# Altium schematic sheet Main.SchDoc (continued)

## Library data of the parts
C1-C7 C9-C19 C21 C22 = CAP 0805 50V: Capacitor SMD 0805 50V; Component Kind: Standard; Library Name: Schlib.SchLib
C8 C23 C24 = CAP 1210 25V: Capacitor SMD 1210 25V; Component Kind: Standard; Library Name: Schlib.SchLib
C20 = CAP 1210 50V: Capacitor SMD 1210 50V; Component Kind: Standard; Library Name: Schlib.SchLib
D1 D4 D5 D7 D10 = LED 0805 BLUE: LED 0805 GREEN SMD; Component Kind: Standard; Library Name: Schlib.SchLib
D2 D6 D8 D9 = ESDS304DBVR: Trans Voltage Suppressor Diode, 85W, 3.6V V(RWM), Unidirectional, 4 Element, Silicon; Manufacturer: Texas Instruments; Category: TVS Diodes; Component Kind: Standard; ComponentLink1Description: https://www.ti.com:443/lit/ds/symlink/esds302.pdf?src-supplier=IHS+Markit; ComponentLink1URL: https://www.ti.com:443/lit/ds/symlink/esds302.pdf?src-supplier=IHS+Markit; ComponentLink2Description: http://www.ti.com/lit/ds/symlink/esds302.pdf?src-supplier=Digikey; ComponentLink2URL: http://www.ti.com/lit/ds/symlink/esds302.pdf?src-supplier=Digikey; Diode Type: TRANS VOLTAGE SUPPRESSOR; JESD-609 Code: e3; Library Name: Schlib.SchLib; Manufacturer Part Number: ESDS304DBVR; Moisture Sensitivity Level: 1; Pricing: 1=0,45, 10=0,304, 50=0,304, 100=0,282, 1000=0,202, 10000=0,15 (USD); Reach SVHC Compliant: Yes; RoHS: Yes; RoHS Compliant: Yes; Stock: 132; Supplier: Mouser; Supplier Part Number: 595-ESDS304DBVR; Terminal Finish: Tin
D3 = ESDA6V1BC6: Trans Voltage Suppressor Diode, 80W, 5V V(RWM), Bidirectional, 4 Element, Silicon; Manufacturer: STMicroelectronics; Max Breakdown Voltage: 8V; Min Breakdown Voltage: 6.1V; Number of Elements: 4; Package Body Material: Plastic; Qualification Status: Not Qualified; Time @ Peak Reflow Temperature-Max (s): 30s; Category: TVS Diodes; Component Kind: Standard; ComponentLink1Description: https://datasheet.ciiva.com:443/pdfs/VipMasterIC/IC/SGST/SGSTS47549/SGSTS47549-1.pdf?src-supplier=IHS+Markit; ComponentLink1URL: https://datasheet.ciiva.com:443/pdfs/VipMasterIC/IC/SGST/SGSTS47549/SGSTS47549-1.pdf?src-supplier=IHS+Markit; ComponentLink2Description: https://datasheet.ciiva.com/14186/esda6v1bc6-st-eng-tds-14186554.pdf?src-supplier=Distrelec; ComponentLink2URL: https://datasheet.ciiva.com/14186/esda6v1bc6-st-eng-tds-14186554.pdf?src-supplier=Distrelec; ComponentLink3Description: https://datasheet.ciiva.com/4507/cd00001906-94538-4507893.pdf?src-supplier=Mouser; ComponentLink3URL: https://datasheet.ciiva.com/4507/cd00001906-94538-4507893.pdf?src-supplier=Mouser; ComponentLink4Description: https://datasheet.ciiva.com/15143/getdatasheetpartid-148472-15143793.pdf?src-supplier=Verical; ComponentLink4URL: https://datasheet.ciiva.com/15143/getdatasheetpartid-148472-15143793.pdf?src-supplier=Verical; ComponentLink5Description: https://datasheet.ciiva.com/7662/1671767-7662124.pdf?src-supplier=Element14; ComponentLink5URL: https://datasheet.ciiva.com/7662/1671767-7662124.pdf?src-supplier=Element14; ComponentLink6Description: https://datasheet.ciiva.com/338/cd00001906-338704.pdf?src-supplier=Digikey; ComponentLink6URL: https://datasheet.ciiva.com/338/cd00001906-338704.pdf?src-supplier=Digikey; ComponentLink7Description: https://datasheet.ciiva.com/338/cd00001906-338705.pdf?src-supplier=Digikey; ComponentLink7URL: https://datasheet.ciiva.com/338/cd00001906-338705.pdf?src-supplier=Digikey; ComponentLink8Description: https://datasheet.ciiva.com/11194/cd00001906-11194053.pdf?src-supplier=Avnet; ComponentLink8URL: https://datasheet.ciiva.com/11194/cd00001906-11194053.pdf?src-supplier=Avnet; Diode Element Material: SILICON; Diode Type: TRANS VOLTAGE SUPPRESSOR; JESD-30 Code: R-PDSO-G6; JESD-609 Code: e4; Library Name: Schlib.SchLib; Manufacturer Part Number: ESDA6V1BC6; Max Operating Temperature: 150°C; Moisture Sensitivity Level: 1; Non-rep Peak Rev Power Dis-Max: 80W; Package Shape: Rectangular; Package Style: SMALL OUTLINEMeter; Peak Reflow Temperature (Cel): 260°C; Pins: 6; Polarity: Bidirectional; Pricing: 3000=0,1532 (USD); Reach SVHC Compliant: Yes; Rep Pk Reverse Voltage-Max: 5V; RoHS: Yes; RoHS Compliant: Yes; Stock: 84000; Supplier: Arrow Electronics; Supplier Part Number: ESDA6V1BC6; Surface Mount: Yes; Technology: AVALANCHE; Terminal Finish: Nickel; Terminal Form: Gull Wing; Terminal Position: DUAL
D11 = SS26-E3/52T: Rectifier Diode, Schottky, 1 Phase, 1 Element, 2A, 60V V(RRM), Silicon, DO-214AA; Manufacturer: Vishay Semiconductors; Application(s): EFFICIENCY; Forward Voltage: 700mV; Non-rep Pk Forward Current-Max: 75A; Number of Elements: 1; Package Body Material: Plastic; Qualification Status: Not Qualified; Category: Rectifier Diodes; Component Kind: Standard; ComponentLink1Description: https://datasheet.ciiva.com:443/pdfs/VipMasterIC/IC/VISH/VISH-S-A0003397022/VISH-S-A0003397022-1.pdf?src-supplier=IHS+Markit; ComponentLink1URL: https://datasheet.ciiva.com:443/pdfs/VipMasterIC/IC/VISH/VISH-S-A0003397022/VISH-S-A0003397022-1.pdf?src-supplier=IHS+Markit; ComponentLink2Description: http://www.farnell.com/datasheets/2243773.pdf?src-supplier=Farnell; ComponentLink2URL: http://www.farnell.com/datasheets/2243773.pdf?src-supplier=Farnell; ComponentLink3Description: http://www.mouser.com/ds/2/427/ss22-59686.pdf?src-supplier=Mouser; ComponentLink3URL: http://www.mouser.com/ds/2/427/ss22-59686.pdf?src-supplier=Mouser; ComponentLink4Description: http://components.arrow.com/part/dataview/42820119S9715575N5680?src-supplier=Arrow+Electronics; ComponentLink4URL: http://components.arrow.com/part/dataview/42820119S9715575N5680?src-supplier=Arrow+Electronics; ComponentLink5Description: https://www.alliedelec.com/m/d/a5cdc6a9796d04f8094106d10cac2262.pdf?src-supplier=Allied+Electronics; ComponentLink5URL: https://www.alliedelec.com/m/d/a5cdc6a9796d04f8094106d10cac2262.pdf?src-supplier=Allied+Electronics; ComponentLink6Description: http://www.produktinfo.conrad.com/datenblaetter/575000-599999/596527-da-01-en-DIODE_SCHOTTKY_SS26_E3_52T_DO_214AA_VIS.pdf?src-supplier=Conrad; ComponentLink6URL: http://www.produktinfo.conrad.com/datenblaetter/575000-599999/596527-da-01-en-DIODE_SCHOTTKY_SS26_E3_52T_DO_214AA_VIS.pdf?src-supplier=Conrad; ComponentLink7Description: http://www.vishay.com/docs/88748/88748.pdf?src-supplier=Future+Electronics; ComponentLink7URL: http://www.vishay.com/docs/88748/88748.pdf?src-supplier=Future+Electronics; ComponentLink8Description: https://datasheet.octopart.com/SS26-E3-52T-Vishay-datasheet-11549126.pdf?src-supplier=Newark; ComponentLink8URL: https://datasheet.octopart.com/SS26-E3-52T-Vishay-datasheet-11549126.pdf?src-supplier=Newark; ComponentLink9Description: https://datasheet.octopart.com/SS26-E3-52T-Vishay-datasheet-78862754.pdf?src-supplier=Element14; ComponentLink9URL: https://datasheet.octopart.com/SS26-E3-52T-Vishay-datasheet-78862754.pdf?src-supplier=Element14; ComponentLink10Description: http://www.farnell.com/datasheets/2243773.pdf?src-supplier=Newark; ComponentLink10URL: http://www.farnell.com/datasheets/2243773.pdf?src-supplier=Newark; ComponentLink11Description: https://datasheet.octopart.com/SS26-E3/52T-Vishay-datasheet-126433.pdf?src-supplier=Digikey; ComponentLink11URL: https://datasheet.octopart.com/SS26-E3/52T-Vishay-datasheet-126433.pdf?src-supplier=Digikey; ComponentLink12Description: http://www.vishay.com/docs/88748/88748.pdf?src-supplier=Avnet; ComponentLink12URL: http://www.vishay.com/docs/88748/88748.pdf?src-supplier=Avnet; ComponentLink13Description: http://www.vishay.com/docs/88748/ss22.pdf?src-supplier=Digikey; ComponentLink13URL: http://www.vishay.com/docs/88748/ss22.pdf?src-supplier=Digikey; ComponentLink14Description: http://docs-usa.electrocomponents.com/techlib:/itc_doclist_US.htm?stockno=7000962&src-supplier=Allied+Electronics; ComponentLink14URL: http://docs-usa.electrocomponents.com/techlib:/itc_doclist_US.htm?stockno=7000962&src-supplier=Allied+Electronics; ComponentLink15Description: https://datasheet.octopart.com/SS26-E3-52T-Vishay-datasheet-42673615.pdf?src-supplier=TME; ComponentLink15URL: https://datasheet.octopart.com/SS26-E3-52T-Vishay-datasheet-42673615.pdf?src-supplier=TME; Diode Element Material: SILICON; Diode Type: RECTIFIER; JEDEC-95 Code: DO-214AA; JESD-30 Code: R-PDSO-C2; JESD-609 Code: e3; Library Name: Schlib.SchLib; Manufacturer Part Number: SS26-E3/52T; Max Operating Temperature: 150°C; Max Output current: 2A; Min Operating Temperature: -65°C; Moisture Sensitivity Level: 1; Number of Phases: 1; Package Shape: Rectangular; Package Style: SMALL OUTLINEMeter; Peak Reflow Temperature (Cel): 260°C; Pins: 2; Pricing: 750=0,1023 (USD); Reach SVHC Compliant: No; Rep Pk Reverse Voltage-Max: 60V; RoHS: Yes; RoHS Compliant: Yes; Stock: 1482000; Supplier: Arrow Electronics; Supplier Part Number: SS26-E3/52T; Surface Mount: Yes; Technology: SCHOTTKY; Terminal Finish: Tin; Terminal Form: C BEND; Terminal Position: DUAL
D12 = SMBJ8.5CA: Diode TVS Single Bi-Dir 8.5V 600W 2-Pin SMB; Manufacturer: Bourns; Breakdown Voltage: 10.8V; Clamping Voltage: 137V; Diode Type: ZENER; Direction: Bidirectional; Leakage Current: 1uA; Max Reverse Leakage Current: 40uA; Max Surge Current: 100A; Min Breakdown Voltage: 9.44V; Number of Bidirectional Channels: 1; Operating Supply Voltage: 3.5V; Peak Pulse Current: 41.7A; Power Line Protection: No; Reverse Breakdown Voltage: 94.4V; Test Current: 1mA; Case/Package: DO-214AA; Category: TVS Diodes; Component Kind: Standard; ComponentLink1Description: https://datasheet.ciiva.com/4510/smbj-51809-4510857.pdf?src-supplier=Mouser; ComponentLink1URL: https://datasheet.ciiva.com/4510/smbj-51809-4510857.pdf?src-supplier=Mouser; ComponentLink2Description: https://datasheet.ciiva.com/3022/smbj-3022665.pdf?src-supplier=Avnet; ComponentLink2URL: https://datasheet.ciiva.com/3022/smbj-3022665.pdf?src-supplier=Avnet; ComponentLink3Description: https://datasheet.ciiva.com/3022/smbj-3022666.pdf?src-supplier=Avnet; ComponentLink3URL: https://datasheet.ciiva.com/3022/smbj-3022666.pdf?src-supplier=Avnet; ComponentLink4Description: https://datasheet.ciiva.com/334/smbj-334791.pdf?src-supplier=Digikey; ComponentLink4URL: https://datasheet.ciiva.com/334/smbj-334791.pdf?src-supplier=Digikey; ComponentLink5Description: https://datasheet.ciiva.com/334/smbj-334792.pdf?src-supplier=Digikey; ComponentLink5URL: https://datasheet.ciiva.com/334/smbj-334792.pdf?src-supplier=Digikey; ComponentLink6Description: https://datasheet.ciiva.com/334/smbj-334790.pdf?src-supplier=Digikey; ComponentLink6URL: https://datasheet.ciiva.com/334/smbj-334790.pdf?src-supplier=Digikey; ComponentLink7Description: https://datasheet.ciiva.com/3022/smbj-3022670.pdf?src-supplier=Avnet; ComponentLink7URL: https://datasheet.ciiva.com/3022/smbj-3022670.pdf?src-supplier=Avnet; ComponentLink8Description: https://datasheet.ciiva.com/334/smbj-334764.pdf?src-supplier=Digikey; ComponentLink8URL: https://datasheet.ciiva.com/334/smbj-334764.pdf?src-supplier=Digikey; ComponentLink9Description: https://datasheet.ciiva.com/334/smbj-334765.pdf?src-supplier=Digikey; ComponentLink9URL: https://datasheet.ciiva.com/334/smbj-334765.pdf?src-supplier=Digikey; ComponentLink10Description: https://datasheet.ciiva.com/334/smbj-334763.pdf?src-supplier=Digikey; ComponentLink10URL: https://datasheet.ciiva.com/334/smbj-334763.pdf?src-supplier=Digikey; Library Name: Schlib.SchLib; Manufacturer Part Number: SMBJ8.5CA; Max Breakdown Voltage: 10.8V; Max Operating Temperature: 150°C; Max Power Dissipation: 600W; Min Operating Temperature: -55°C; Mount: Surface Mount; Number of Channels: 1; Number of Elements: 1; Number of Pins: 2; Packaging: Tape and Reel; Peak Pulse Power: 600W; Polarity: Bidirectional; Pricing 1: 12000=0,0994, 18000=0,0973, 30000=0,0952, 60000=0,0931, 120000=0,091, 600000=0,0889, 1200000=0,0875 (USD); Radiation Hardening: No; Reach SVHC Compliant: No; Reverse Standoff Voltage: 8.5V; RoHS: Yes; RoHS Compliant: Yes; Series: SMBJ; Supplier 1: Avnet; Termination: SMD/SMT
D13 D14 = LED 0805 RED: LED 0805 RED SMD; Component Kind: Standard; Library Name: Schlib.SchLib
F1 = 0ZCG0150BF2C: PTC RESTTBLE 1.50A 24V CHIP 1812; Manufacturer: Bel; Current Rating: 100A; Category: PTC Resettable Fuses; Component Kind: Standard; ComponentLink1Description: http://www.farnell.com/datasheets/2571640.pdf?src-supplier=Farnell; ComponentLink1URL: http://www.farnell.com/datasheets/2571640.pdf?src-supplier=Farnell; ComponentLink2Description: http://www.farnell.com/datasheets/2571640.pdf?src-supplier=Element14; ComponentLink2URL: http://www.farnell.com/datasheets/2571640.pdf?src-supplier=Element14; ComponentLink3Description: https://www.belfuse.com/resources/CircuitProtection/datasheets/0ZCG%20Nov2016.pdf?src-supplier=Digikey; ComponentLink3URL: https://www.belfuse.com/resources/CircuitProtection/datasheets/0ZCG%20Nov2016.pdf?src-supplier=Digikey; ComponentLink4Description: http://www.farnell.com/datasheets/2571640.pdf?src-supplier=Newark; ComponentLink4URL: http://www.farnell.com/datasheets/2571640.pdf?src-supplier=Newark; ComponentLink5Description: http://www.belfuse.com/pdfs/0ZCG.pdf?src-supplier=Avnet; ComponentLink5URL: http://www.belfuse.com/pdfs/0ZCG.pdf?src-supplier=Avnet; ComponentLink6Description: https://datasheet.octopart.com/0ZCG0150BF2C-Bel-Fuse-datasheet-138895723.pdf?src-supplier=Electro+Sonic; ComponentLink6URL: https://datasheet.octopart.com/0ZCG0150BF2C-Bel-Fuse-datasheet-138895723.pdf?src-supplier=Electro+Sonic; Height: 1.55mm; Library Name: Schlib.SchLib; Manufacturer Part Number: 0ZCG0150BF2C; Pricing 1: 2000=0,10628, 4000=0,10217, 8000=0,09874, 12000=0,09531, 16000=0,09188, 20000=0,08846, 200000=0,06138 (USD); RoHS: Yes; Stock 1: 20000; Supplier 1: Avnet; Voltage Rating: 24V
FB1 FB2 = FB 0805: Ferrite Bead SMD 0805; Component Kind: Standard; Library Name: Schlib.SchLib
J1 = 61400416121: USB Connector, 4 Contact(s), Female, Right Angle, Solder Terminal, Locking, Receptacle; Manufacturer: Wurth Electronics; Additional Feature: ROHS COMPLIANT; Board Mounting Option: SOLDERABLE RETENTION CLIPS; Body Breadth: 0.421inch; Body Depth: 0.65inch; Body Length: 0.472inch; Connector Type: TELECOM AND DATACOM CONNECTOR; Contact Resistance: 20mR; Dielectric Withstanding Voltage (AC): 750V; Durability: 1500Cycles; DIN Conformance: No; Number Of Connectors: 1; Number of Contacts: 4; Number Of PCB Rows: 2; Option: General Purpose; PCB Contact Pattern: RECTANGULAR; PCB Contact Row Spacing: 2.0066mm; Polarization Key: POLARIZED HOUSING; Rated Current (Signal): 1.5A; Reference Standard: UL; Reliability: COMMERCIAL; Insulation Resistance: 1GR; Body/Shell Style: RECEPTACLE; Category: USB Connectors; Component Kind: Standard; ComponentLink1Description: https://datasheet.ciiva.com:443/pdfs/VipMasterIC/IC/MIDC/MIDC-S-A0004022663/MIDC-S-A0006489655-1.pdf?src-supplier=IHS+Markit; ComponentLink1URL: https://datasheet.ciiva.com:443/pdfs/VipMasterIC/IC/MIDC/MIDC-S-A0004022663/MIDC-S-A0006489655-1.pdf?src-supplier=IHS+Markit; ComponentLink2Description: https://datasheet.ciiva.com/1098/1697135-1098929.pdf?src-supplier=Element14; ComponentLink2URL: https://datasheet.ciiva.com/1098/1697135-1098929.pdf?src-supplier=Element14; ComponentLink3Description: https://datasheet.ciiva.com/1100/76589-1100560.pdf?src-supplier=Newark; ComponentLink3URL: https://datasheet.ciiva.com/1100/76589-1100560.pdf?src-supplier=Newark; ComponentLink4Description: https://datasheet.ciiva.com/4598/61400416121-69623-4598782.pdf?src-supplier=Mouser; ComponentLink4URL: https://datasheet.ciiva.com/4598/61400416121-69623-4598782.pdf?src-supplier=Mouser; ComponentLink5Description: https://datasheet.ciiva.com/851/61400416121-851771.pdf?src-supplier=Digikey; ComponentLink5URL: https://datasheet.ciiva.com/851/61400416121-851771.pdf?src-supplier=Digikey; ComponentLink6Description: https://datasheet.ciiva.com/11731/0900766b81023ab5-11731301.pdf?src-supplier=Rs; ComponentLink6URL: https://datasheet.ciiva.com/11731/0900766b81023ab5-11731301.pdf?src-supplier=Rs; ComponentLink7Description: https://datasheet.ciiva.com/14118/4257098-eng-datasheet-14118442.pdf?src-supplier=Distrelec; ComponentLink7URL: https://datasheet.ciiva.com/14118/4257098-eng-datasheet-14118442.pdf?src-supplier=Distrelec; ComponentLink8Description: https://datasheet.ciiva.com/48712/001088208-da-01-en-wr-com-usb-type-b-61400416121-48712662.pdf?src-supplier=Conrad; ComponentLink8URL: https://datasheet.ciiva.com/48712/001088208-da-01-en-wr-com-usb-type-b-61400416121-48712662.pdf?src-supplier=Conrad; Contact Finish - Mating: GOLD; Contact Finish - Termination: Gold (Au); Contact Gender: Female; Contact Material: Copper Alloy; Contact Style: USB; Empty Shell: No; Filter Feature: No; Flammability Rating: UL94 V-0; JESD-609 Code: e4; Library Name: Schlib.SchLib; Manufacturer Part Number: 61400416121; Mating Information: NO; Max Operating Temperature: 105°C; Min Operating Temperature: -40°C; Mixed Contacts: No; Mounting Option 1: LOCKING; Mounting Style: RIGHT ANGLE; Mounting Technology: Board; MIL Conformance: No; Pricing: 1=1,41, 10=1,24, 50=1,17, 100=1,05, 1000=1,01, 10000=1,01 (USD); Reach SVHC Compliant: Yes; RoHS: Yes; RoHS Compliant: Yes; Shell Finish: Nickel; Shell Material: Bronze; Stock: 3231; Supplier: Mouser; Supplier Part Number: 710-61400416121; Terminal Length: 0.126inch; Terminal Pitch: 2.4892mm; Termination: Solder; IEC Conformance: No; Insulation Material: Polybutylene
J3 = 693072010801: PCB Connector, 8 Contact(s), 1 Row(s), Male, Right Angle, 0.043 inch Pitch, Surface Mount Terminal, Black Insulator, Receptacle; Manufacturer: Wurth Electronics; Board Mounting Option: SOLDER HOLDING POSTS; Body Breadth: 0.069inch; Body Depth: 0.539inch; Body Length: 0.535inch; Contact Pattern: RECTANGULAR; Contact Resistance: 100mR; Dielectric Withstanding Voltage (AC): 500V; Durability: 10000Cycles; Number Of Connectors: 1; Number of Contacts: 8; Rated Current (Signal): 500mA; Reference Standard: UL; Reliability: COMMERCIAL; Insulation Resistance: 1GR; Body/Shell Style: RECEPTACLE; Category: Memory Connectors; Component Kind: Standard; ComponentLink1Description: https://datasheet.ciiva.com:443/pdfs/VipMasterIC/IC/MIDC/MIDC-S-A0000106158/MIDC-S-A0000106158-1.pdf?src-supplier=IHS+Markit; ComponentLink1URL: https://datasheet.ciiva.com:443/pdfs/VipMasterIC/IC/MIDC/MIDC-S-A0000106158/MIDC-S-A0000106158-1.pdf?src-supplier=IHS+Markit; ComponentLink2Description: https://datasheet.ciiva.com/1107/693072010801-1107219.pdf?src-supplier=Newark; ComponentLink2URL: https://datasheet.ciiva.com/1107/693072010801-1107219.pdf?src-supplier=Newark; ComponentLink3Description: https://datasheet.ciiva.com/25605/0900766b81113f29-25605360.pdf?src-supplier=Rs; ComponentLink3URL: https://datasheet.ciiva.com/25605/0900766b81113f29-25605360.pdf?src-supplier=Rs; ComponentLink4Description: https://datasheet.ciiva.com/1104/693072010801-1104797.pdf?src-supplier=Element14; ComponentLink4URL: https://datasheet.ciiva.com/1104/693072010801-1104797.pdf?src-supplier=Element14; ComponentLink5Description: https://datasheet.ciiva.com/6935/693072010801-6935071.pdf?src-supplier=Digikey; ComponentLink5URL: https://datasheet.ciiva.com/6935/693072010801-6935071.pdf?src-supplier=Digikey; ComponentLink6Description: https://datasheet.ciiva.com/6935/693072010801-6935068.pdf?src-supplier=Digikey; ComponentLink6URL: https://datasheet.ciiva.com/6935/693072010801-6935068.pdf?src-supplier=Digikey; Connector Type: PCB; Contact Finish - Mating: NOT SPECIFIED; Contact Gender: Male; Library Name: Schlib.SchLib; Manufacturer Part Number: 693072010801; Mating Contact Pitch: 0.043inch; Max Operating Temperature: 85°C; Min Operating Temperature: -25°C; Mounting Style: RIGHT ANGLE; Mounting Technology: Board; Number Of PCB Rows: 1; Number of Ports: 1; Number of Rows Loaded: 1; PCB Contact Pattern: RECTANGULAR; Pricing: 1=3,48, 10=3,41, 50=3, 100=2,87, 1000=2,46, 10000=2,26 (USD); Reach SVHC Compliant: Yes; RoHS: Yes; RoHS Compliant: Yes; Stock: 2877; Supplier: Mouser; Supplier Part Number: 710-693072010801; Terminal Pitch: 1.0922mm; Termination: SMD/SMT; Insulation Color: Black; Insulation Material: Polymer
J4 = E22-400T30D: SX1268 E22-400T30D LoRa Module Header; Component Kind: Standard; Library Name: Schlib.SchLib
J5 = KF350 2P Terminal: 2 Pin Screw Terminal Block 3.5mm; Component Kind: Standard; Library Name: Schlib.SchLib
L1 = IHLP2020CZER4R7M11: Inductor Power Shielded Wirewound 4.7uH 20% 100KHz Powdered Iron 4.5A 60mOhm DCR 2020 T/R; Manufacturer: Vishay; Category: Fixed Inductors; Component Kind: Standard; ComponentLink1Description: https://datasheet.ciiva.com/14803/lp20cz11-14803040.pdf?src-supplier=Arrow+Electronics; ComponentLink1URL: https://datasheet.ciiva.com/14803/lp20cz11-14803040.pdf?src-supplier=Arrow+Electronics; ComponentLink2Description: https://datasheet.ciiva.com/11798/0900766b8102c930-11798346.pdf?src-supplier=Rs; ComponentLink2URL: https://datasheet.ciiva.com/11798/0900766b8102c930-11798346.pdf?src-supplier=Rs; ComponentLink3Description: https://datasheet.ciiva.com/22694/480942-22694470.pdf?src-supplier=Element14; ComponentLink3URL: https://datasheet.ciiva.com/22694/480942-22694470.pdf?src-supplier=Element14; ComponentLink4Description: https://datasheet.ciiva.com/8017/480942-8017228.pdf?src-supplier=Element14; ComponentLink4URL: https://datasheet.ciiva.com/8017/480942-8017228.pdf?src-supplier=Element14; ComponentLink5Description: https://datasheet.ciiva.com/8494/lp20cz11-8494950.pdf?src-supplier=Avnet; ComponentLink5URL: https://datasheet.ciiva.com/8494/lp20cz11-8494950.pdf?src-supplier=Avnet; ComponentLink6Description: https://datasheet.ciiva.com/17112/70205433-17112327.pdf?src-supplier=Allied+Electronics; ComponentLink6URL: https://datasheet.ciiva.com/17112/70205433-17112327.pdf?src-supplier=Allied+Electronics; ComponentLink7Description: https://datasheet.ciiva.com/6688/lp20cz11-6688326.pdf?src-supplier=Digikey; ComponentLink7URL: https://datasheet.ciiva.com/6688/lp20cz11-6688326.pdf?src-supplier=Digikey; ComponentLink8Description: https://datasheet.ciiva.com/6688/lp20cz11-6688327.pdf?src-supplier=Digikey; ComponentLink8URL: https://datasheet.ciiva.com/6688/lp20cz11-6688327.pdf?src-supplier=Digikey; ComponentLink9Description: https://datasheet.ciiva.com/6688/lp20cz11-6688329.pdf?src-supplier=Digikey; ComponentLink9URL: https://datasheet.ciiva.com/6688/lp20cz11-6688329.pdf?src-supplier=Digikey; Library Name: Schlib.SchLib; Manufacturer Part Number: IHLP2020CZER4R7M11; Pricing 1: 1=1,19, 10=1,02, 50=1,02, 100=0,828, 1000=0,828, 10000=0,473 (USD); RoHS: No; Stock 1: 10031; Supplier 1: Mouser
Q1 Q2 = BC817-25W,115: BC817 Series 45 V 500 mA NPN General Purpose Transistor - SOT-23; Manufacturer: Nexperia; Category: BJTs; Component Kind: Standard; ComponentLink1Description: https://datasheet.octopart.com/BC817-25W%2C115-NXP-datasheet-29749.pdf?src-supplier=Future+Electronics; ComponentLink1URL: https://datasheet.octopart.com/BC817-25W%2C115-NXP-datasheet-29749.pdf?src-supplier=Future+Electronics; ComponentLink2Description: http://www.farnell.com/datasheets/699988.pdf?src-supplier=Newark; ComponentLink2URL: http://www.farnell.com/datasheets/699988.pdf?src-supplier=Newark; ComponentLink3Description: https://datasheet.octopart.com/BC817-25W%2C115-Nexperia-datasheet-155782301.pdf?src-supplier=TME; ComponentLink3URL: https://datasheet.octopart.com/BC817-25W%2C115-Nexperia-datasheet-155782301.pdf?src-supplier=TME; Library Name: Schlib.SchLib; Manufacturer Part Number: BC817-25W,115; Pricing 1: 3000=0,0169, 12000=0,0162, 45000=0,0155, 90000=0,0152, 225000=0,0144 (USD); RoHS: Yes; Stock 1: 99000; Supplier 1: Future Electronics; Supplier Part Number 1: 5067352
R1-R31 = RES 0805: Resistor SMD 0805; Component Kind: Standard; Library Name: Schlib.SchLib
S1-S5 = FSM4JSMATR: Switch Tactile OFF (ON) SPST Round Button Gull Wing 0.05A 24VDC 1.57N SMD T/R; Manufacturer: TE Connectivity Alcoswitch; Category: Pushbutton Switches; Component Kind: Standard; ComponentLink1Description: https://datasheet.ciiva.com/16320/eng-cs-1308111-1-switches-core-program-catalog-0308-16320065.pdf?src-supplier=Future+Electronics; ComponentLink1URL: https://datasheet.ciiva.com/16320/eng-cs-1308111-1-switches-core-program-catalog-0308-16320065.pdf?src-supplier=Future+Electronics; ComponentLink2Description: https://datasheet.ciiva.com/1530/1683375-1530944.pdf?src-supplier=Element14; ComponentLink2URL: https://datasheet.ciiva.com/1530/1683375-1530944.pdf?src-supplier=Element14; ComponentLink3Description: https://datasheet.ciiva.com/23765/1486934-23765878.pdf?src-supplier=Newark; ComponentLink3URL: https://datasheet.ciiva.com/23765/1486934-23765878.pdf?src-supplier=Newark; ComponentLink4Description: https://datasheet.ciiva.com/7638/921681-7638037.pdf?src-supplier=Element14; ComponentLink4URL: https://datasheet.ciiva.com/7638/921681-7638037.pdf?src-supplier=Element14; Library Name: Schlib.SchLib; Manufacturer Part Number: FSM4JSMATR; Pricing 1: 1=0,36, 10=0,351, 25=0,33, 100=0,2866, 250=0,26, 500=0,24188 (USD); RoHS: Yes; Stock 1: 84996; Supplier 1: Digi-Key
S6-S8 = MTS-103: Miniature Toggle Switch Single Pole Double Throw SPDT (MTS103) ON-OFF-ON 120VAC 5A 1/4 Inch Mounting; Component Kind: Standard; Library Name: Schlib.SchLib
U1 = FT232RL-REEL: IC USB FS SERIAL UART 28-SSOP; Manufacturer: FTDI; Data Rate: 12Mbps; Max Supply Voltage: 5.25V; Min Supply Voltage: 3.3V; Number of Channels: 1; Operating Supply Current: 15mA; Voltage: 5V; Interface: UART,RS-232,RS-422,RS-485; Case/Package: SSOP; Category: Interface ICs; Component Kind: Standard; ComponentLink1Description: https://datasheet.ciiva.com/34304/2161019-34304054.pdf?src-supplier=Jameco; ComponentLink1URL: https://datasheet.ciiva.com/34304/2161019-34304054.pdf?src-supplier=Jameco; ComponentLink2Description: https://datasheet.ciiva.com/4787/ds-ft232r-11534-4787658.pdf?src-supplier=Mouser; ComponentLink2URL: https://datasheet.ciiva.com/4787/ds-ft232r-11534-4787658.pdf?src-supplier=Mouser; ComponentLink3Description: https://datasheet.ciiva.com/1787/ds-ft232r-1787048.pdf?src-supplier=Digikey; ComponentLink3URL: https://datasheet.ciiva.com/1787/ds-ft232r-1787048.pdf?src-supplier=Digikey; ComponentLink4Description: https://datasheet.ciiva.com/1787/ds-ft232r-1787047.pdf?src-supplier=Digikey; ComponentLink4URL: https://datasheet.ciiva.com/1787/ds-ft232r-1787047.pdf?src-supplier=Digikey; ComponentLink5Description: https://datasheet.ciiva.com/1787/ds-ft232r-1787049.pdf?src-supplier=Digikey; ComponentLink5URL: https://datasheet.ciiva.com/1787/ds-ft232r-1787049.pdf?src-supplier=Digikey; ComponentLink6Description: https://datasheet.ciiva.com/16200/ds-ft232r-16200652.pdf?src-supplier=Future+Electronics; ComponentLink6URL: https://datasheet.ciiva.com/16200/ds-ft232r-16200652.pdf?src-supplier=Future+Electronics; ComponentLink7Description: https://datasheet.ciiva.com/15150/getdatasheetpartid-643207-15150506.pdf?src-supplier=Verical; ComponentLink7URL: https://datasheet.ciiva.com/15150/getdatasheetpartid-643207-15150506.pdf?src-supplier=Verical; Height: 1.75mm; Lead Free: Lead Free; Length: 10.2mm; Library Name: Schlib.SchLib; Manufacturer Part Number: FT232RL-REEL; Max Operating Temperature: 85°C; Min Operating Temperature: -40°C; Mount: Surface Mount; Number of Pins: 28; Number of Transceivers: 1; Package Quantity: 1; Packaging: Tape and Reel; Pricing 1: 1=4,75, 10=4,3, 50=4,1 (USD); Radiation Hardening: No; Reach SVHC Compliant: No; RoHS: Yes; RoHS Compliant: Yes; Series: USBmadeEZ-UART; Stock 1: 3812; Supplier 1: Arrow Electronics; Weight: 0.080001oz; Width: 5.3mm
U2 = ESP32-WROOM-32D(4MB): Rx Txrx Mod Wifi Trace Ant SMD; Manufacturer: Espressif Systems; Category: LDO; Component Kind: Standard; Library Name: Schlib.SchLib; Manufacturer Part Number: ESP32-WROOM-32D(4MB); RoHS: No; Supplier: Mouser; Supplier Part Number: 356-ESP32WROOM32D4MB
U4 U5 = CD74HC4052M96: Differential Multiplexer, 1 Func, 2 Channel, CMOS, PDSO16; Manufacturer: Texas Instruments; Channels: 1; Max Supply Voltage: 6V; Min Supply Voltage: 2V; Neg Supply Voltage-Max (Vsup): -6V; Neg Supply Voltage-Min (Vsup): 0V; Neg Supply Voltage-Nom (Vsup): -4.5V; Nominal Supply Voltage: 4.5V; Number of Channels: 4; Off-state Isolation-Nom: 65dB; On-state Resistance Match-Nom: 5R; On-state Resistance-Max (Ron): 130R; Package Body Material: Plastic; Package Equivalence Code: SOP16,.25; Power Supplies: 2/6,GND/-6V; Qualification Status: Not Qualified; Signal Current-Max: 25mA; Supply Current-Max (Isup): 320uA; Switch-off Time-Max: 38ns; Switch-on Time-Max: 46ns; Switching: BREAK-BEFORE-MAKEV; Case/Package: SOP; Category: Decoders and Multiplexers; Component Kind: Standard; ComponentLink1Description: https://www.ti.com:443/lit/ds/symlink/cd54hc4051.pdf?src-supplier=IHS+Markit; ComponentLink1URL: https://www.ti.com:443/lit/ds/symlink/cd54hc4051.pdf?src-supplier=IHS+Markit; ComponentLink2Description: https://datasheet.ciiva.com/27052/1326627-27052007.pdf?src-supplier=Jameco; ComponentLink2URL: https://datasheet.ciiva.com/27052/1326627-27052007.pdf?src-supplier=Jameco; ComponentLink3Description: https://datasheet.ciiva.com/15127/getdatasheetpartid-296378-15127634.pdf?src-supplier=Verical; ComponentLink3URL: https://datasheet.ciiva.com/15127/getdatasheetpartid-296378-15127634.pdf?src-supplier=Verical; ComponentLink4Description: https://datasheet.ciiva.com/1669/cd74hc4051-1669664.pdf?src-supplier=Digikey; ComponentLink4URL: https://datasheet.ciiva.com/1669/cd74hc4051-1669664.pdf?src-supplier=Digikey; ComponentLink5Description: https://datasheet.ciiva.com/45291/cd74hct4053-45291341.pdf?src-supplier=Avnet; ComponentLink5URL: https://datasheet.ciiva.com/45291/cd74hct4053-45291341.pdf?src-supplier=Avnet; ComponentLink6Description: https://datasheet.ciiva.com/1669/cd74hc4051-1669663.pdf?src-supplier=Digikey; ComponentLink6URL: https://datasheet.ciiva.com/1669/cd74hc4051-1669663.pdf?src-supplier=Digikey; ComponentLink7Description: https://datasheet.ciiva.com/1669/cd74hc4051-1669662.pdf?src-supplier=Digikey; ComponentLink7URL: https://datasheet.ciiva.com/1669/cd74hc4051-1669662.pdf?src-supplier=Digikey; ComponentLink8Description: https://datasheet.ciiva.com/48707/001047747-da-01-en-ic-de-mux-dl-4-cd74hc4052m96-soic-16-tid-48707579.pdf?src-supplier=Conrad; ComponentLink8URL: https://datasheet.ciiva.com/48707/001047747-da-01-en-ic-de-mux-dl-4-cd74hc4052m96-soic-16-tid-48707579.pdf?src-supplier=Conrad; Height - Seated (Max): 1.75mm; JESD-30 Code: R-PDSO-G16; JESD-609 Code: e3; Length: 9.9mm; Library Name: Schlib.SchLib; Manufacturer Part Number: CD74HC4052M96; Max Operating Temperature: 125°C; Min Operating Temperature: -55°C; Moisture Sensitivity Level: 1; Package Shape: Rectangular; Package Style: SMALL OUTLINEMeter; Peak Reflow Temperature (Cel): 260°C; Pins: 16; Pricing: 1=0,35, 10=0,239, 50=0,212, 100=0,183, 1000=0,154, 10000=0,135 (USD); Reach SVHC Compliant: Yes; RoHS: Yes; RoHS Compliant: Yes; Stock: 4443; Supplier: Mouser; Supplier Part Number: 595-CD74HC4052M96; Surface Mount: Yes; Technology: CMOS; Temperature Grade: MILITARY; Terminal Finish: Tin; Terminal Form: Gull Wing; Terminal Pitch: 1.27mm; Terminal Position: DUAL; Throw Configuration: DIFFERENTIAL MULTIPLEXER; Width: 3.9mm
U6 U7 = LMV321ILT: Operational Amplifier, 1 Func, 6000uV Offset-Max, BICMOS, PDSO5; Manufacturer: STMicroelectronics; Architecture: VOLTAGE-FEEDBACK; Average Bias Current-Max (IIB): 95nA; Bias Current-Max (IIB) @25C: 63nA; Channels: 1; Common-mode Reject Ratio-Nom: 95dB; Frequency Compensation: YES; Low-Bias: No; Max Supply Current: 250uA; Nominal Supply Voltage: 5V; Package Body Material: Plastic; Package Equivalence Code: TSOP5/6,.11,37; Power Supplies: 2.7/5V; Qualification Status: Not Qualified; Slew Rate: 0.45V/µs; Supply Voltage Limit-Max: 7V; Time @ Peak Reflow Temperature-Max (s): 30s; Unity Gain BW-Nom: 1.3MHz; Voltage Gain-Min: 3160; Input Offset Voltage-Max: 6mV; Amplifier Type: OPERATIONAL AMPLIFIER; Case/Package: LSSOP; Category: Amplifiers - Op Amps, Buffer, Instrumentation; Component Kind: Standard; ComponentLink1Description: https://datasheet.ciiva.com:443/pdfs/VipMasterIC/IC/SGST/SGST-S-A0009735974/SGST-S-A0009735974-1.pdf?src-supplier=IHS+Markit; ComponentLink1URL: https://datasheet.ciiva.com:443/pdfs/VipMasterIC/IC/SGST/SGST-S-A0009735974/SGST-S-A0009735974-1.pdf?src-supplier=IHS+Markit; ComponentLink2Description: https://datasheet.ciiva.com/8008/1733540-8008505.pdf?src-supplier=Element14; ComponentLink2URL: https://datasheet.ciiva.com/8008/1733540-8008505.pdf?src-supplier=Element14; ComponentLink3Description: https://datasheet.ciiva.com/8008/1733540-8008506.pdf?src-supplier=Element14; ComponentLink3URL: https://datasheet.ciiva.com/8008/1733540-8008506.pdf?src-supplier=Element14; ComponentLink4Description: https://datasheet.ciiva.com/16449/cd00079372-16449002.pdf?src-supplier=Future+Electronics; ComponentLink4URL: https://datasheet.ciiva.com/16449/cd00079372-16449002.pdf?src-supplier=Future+Electronics; ComponentLink5Description: https://datasheet.ciiva.com/11710/cd00079372-11710214.pdf?src-supplier=Avnet; ComponentLink5URL: https://datasheet.ciiva.com/11710/cd00079372-11710214.pdf?src-supplier=Avnet; ComponentLink6Description: https://datasheet.ciiva.com/1795/cd00079372-1795891.pdf?src-supplier=Digikey; ComponentLink6URL: https://datasheet.ciiva.com/1795/cd00079372-1795891.pdf?src-supplier=Digikey; ComponentLink7Description: https://datasheet.ciiva.com/1795/cd00079372-1795892.pdf?src-supplier=Digikey; ComponentLink7URL: https://datasheet.ciiva.com/1795/cd00079372-1795892.pdf?src-supplier=Digikey; ComponentLink8Description: https://datasheet.ciiva.com/1795/cd00079372-1795893.pdf?src-supplier=Digikey; ComponentLink8URL: https://datasheet.ciiva.com/1795/cd00079372-1795893.pdf?src-supplier=Digikey; Height - Seated (Max): 1.45mm; JESD-30 Code: R-PDSO-G5; JESD-609 Code: e3; Length: 2.9mm; Library Name: Schlib.SchLib; Low-Offset: No; Manufacturer Part Number: LMV321ILT; Max Operating Temperature: 125°C; Micropower: Yes; Min Operating Temperature: -40°C; Moisture Sensitivity Level: 1; Package Shape: Rectangular; Package Style: SMALL OUTLINE, LOW PROFILE, SHRINK PITCHMeter; Packaging: Tape and Reel; Peak Reflow Temperature (Cel): 260°C; Pins: 5; Power: No; Pricing: 3000=0,2218, 6000=0,2146, 9000=0,1644 (USD); Programmable Power: No; Reach SVHC Compliant: Yes; RoHS: Yes; RoHS Compliant: Yes; Stock: 1011000; Supplier: Arrow Electronics; Supplier Part Number: LMV321ILT; Surface Mount: Yes; Technology: BICMOS; Temperature Grade: AUTOMOTIVE; Terminal Finish: Tin; Terminal Form: Gull Wing; Terminal Pitch: 0.95mm; Terminal Position: DUAL; Wideband: No; Width: 1.625mm
U8 = AP63205WU-7: AP63205 Series 32 V 2 A 1.1 MHz Synchronous Buck Converter -TSOT-26; Manufacturer: Diodes; Max Duty Cycle: 100%; Max Supply Voltage: 32V; Min Supply Voltage: 3.8V; Nominal Supply Current: 22uA; Number of Outputs: 1NUMBER; Output Current: 2A; Output Voltage: 5V; Switching Frequency: 1.1MHz; Category: Voltage Regulators - Switching; Component Kind: Standard; ComponentLink1Description: https://www.diodes.com/assets/Datasheets/AP63200-AP63201-AP63203-AP63205.pdf?src-supplier=Digikey; ComponentLink1URL: https://www.diodes.com/assets/Datasheets/AP63200-AP63201-AP63203-AP63205.pdf?src-supplier=Digikey; Height: 1mm; Library Name: Schlib.SchLib; Manufacturer Part Number: AP63205WU-7; Max Operating Temperature: 85°C; Min Operating Temperature: -40°C; Pricing 1: 3000=0,33, 6000=0,32 (USD); RoHS: Yes; Stock 1: 45000; Supplier 1: Future Electronics
U9 = AMS1117-3.3: Linear Voltage Regulator IC Positive Fixed 1 Output 1A; Component Kind: Standard; Library Name: Schlib.SchLib
J2 = 1x4 HEADER MALE: Component Kind: Standard; Library Name: Schlib.SchLib
U3 = NX3224X028: Component Kind: Standard; Library Name: Schlib.SchLib
